# Altium schematic sheet HBridge2B.SchDoc(HBridge2B)
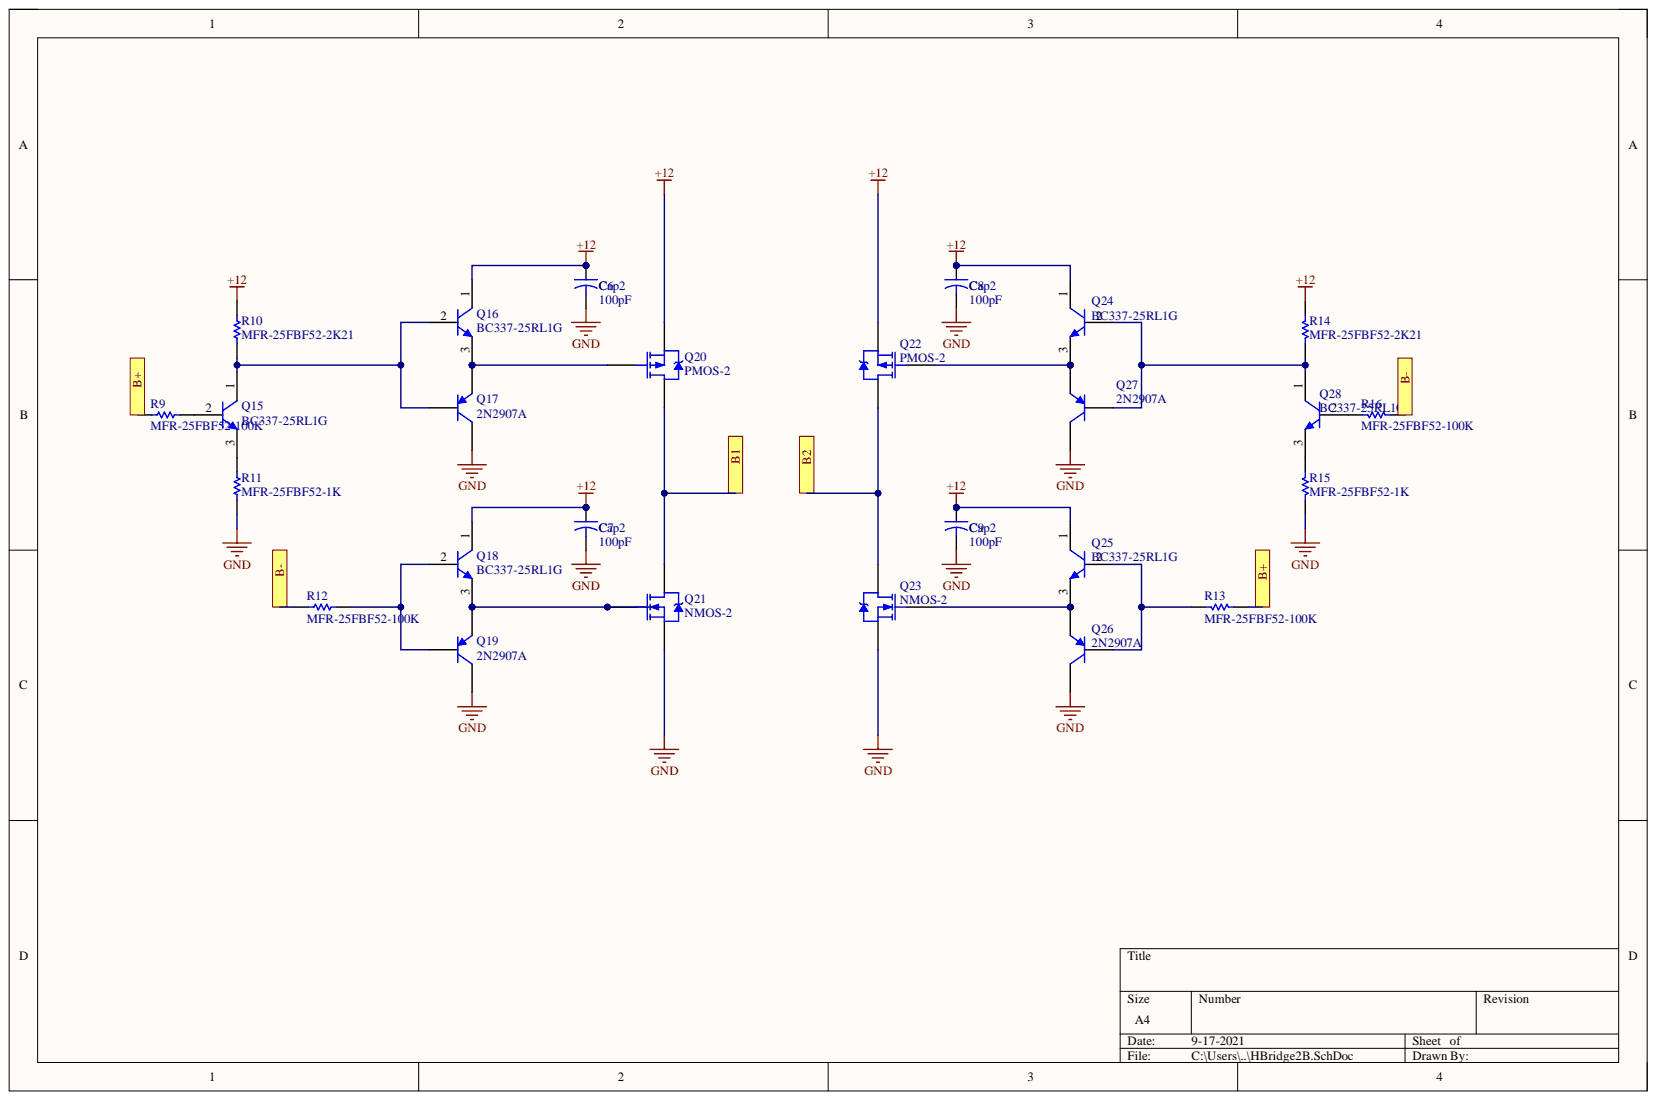
[diagram p0: HBridge2B.SchDoc]

## Parts
C6  Cap2  value 100pF  [AXIAL-0.3]
C7  Cap2  value 100pF  [AXIAL-0.3]
C8  Cap2  value 100pF  [AXIAL-0.3]
C9  Cap2  value 100pF  [AXIAL-0.3]
Q15  BC337-25RL1G  [ONSC-TO-92-3-29-11_AM_BL]
Q16  BC337-25RL1G  [ONSC-TO-92-3-29-11_AM_BL]
Q17  2N2907A  [TO-92A]
Q18  BC337-25RL1G  [ONSC-TO-92-3-29-11_AM_BL]
Q19  2N2907A  [TO-92A]
Q20  PMOS-2  [TO-220AB]
Q21  NMOS-2  [TO-220AB]
Q22  PMOS-2  [TO-220AB]
Q23  NMOS-2  [TO-220AB]
Q24  BC337-25RL1G  [ONSC-TO-92-3-29-11_AM_BL]
Q25  BC337-25RL1G  [ONSC-TO-92-3-29-11_AM_BL]
Q26  2N2907A  [TO-92A]
Q27  2N2907A  [TO-92A]
Q28  BC337-25RL1G  [ONSC-TO-92-3-29-11_AM_BL]
R9  MFR-25FBF52-100K  [RESA60-630X240]
R10  MFR-25FBF52-2K21  [RESA60-630X240]
R11  MFR-25FBF52-1K  [RESA60-630X240]
R12  MFR-25FBF52-100K  [RESA60-630X240]
R13  MFR-25FBF52-100K  [RESA60-630X240]
R14  MFR-25FBF52-2K21  [RESA60-630X240]
R15  MFR-25FBF52-1K  [RESA60-630X240]
R16  MFR-25FBF52-100K  [RESA60-630X240]

## Ports
B1, B2, B+, B-

## Nets
+12: C6.1 C7.1 C8.1 C9.1 Q16.1 Q18.1 Q20.3 Q22.3 Q24.1 Q25.1 R10.2 R14.2
GND: C6.2 C7.2 C8.2 C9.2 Q17.3 Q19.3 Q21.3 Q23.3 Q26.3 Q27.3 R11.1 R15.1
B1: Q20.2 Q21.2 ; port B1
B2: Q22.2 Q23.2 ; port B2
NetQ15_1: Q15.1 Q16.2 Q17.2 R10.1
NetQ15_2: Q15.2 R9.2
NetQ15_3: Q15.3 R11.2
NetQ16_3: Q16.3 Q17.1 Q20.1
NetQ18_2: Q18.2 Q19.2 R12.2
NetQ18_3: Q18.3 Q19.1 Q21.1
NetQ22_1: Q22.1 Q24.3 Q27.1
NetQ23_1: Q23.1 Q25.3 Q26.1
NetQ24_2: Q24.2 Q27.2 Q28.1 R14.1
NetQ25_2: Q25.2 Q26.2 R13.2
NetQ28_2: Q28.2 R16.2
NetQ28_3: Q28.3 R15.2
NetR9_1: R9.1 R13.1
NetR12_1: R12.1 R16.1

## Library data of the parts
C6-C9 = Cap2: Capacitor; Component Kind: Standard; Library Name: Miscellaneous Devices.IntLib; PackageDescription: Capacitor; 2 Leads; PackageReference: CAPR5-4X5; Published: 23-Sep-2002; Signal Integrity: Cap; Simulation: CAP; Publisher: Altium Limited
Q15 Q16 Q18 Q24 Q25 Q28 = CMP-1048-01576-1: Amplifier Transistor, NPN Silicon, 3-Pin TO-92, Pb-Free, Tape and Reel; Manufacturer: On Semiconductor; IC Continuous (A): 0.8; Component Kind: Standard; ComponentLink1Description: Manufacturer URL; ComponentLink1URL: http://www.onsemi.com/; ComponentLink2Description: Datasheet; ComponentLink2URL: http://www.onsemi.com/pub_link/Collateral/BC337-D.PDF; ComponentLink3Description: Package Specification; ComponentLink3URL: http://www.onsemi.com/pub_link/Collateral/MAC97-D.PDF; DatasheetVersion: Rev. 8; Library Name: Altium Content Vault; Mounting Technology: Through Hole; PackageDescription: 3-Pin Transistor Outline, Body 4.825 x 3.685 mm, Pitch 1.27 mm; PackageReference: TO-92-3-29-11_AM_BL; PackageVersion: Rev. AM; Packing: Tape and Reel; PartNumber: BC337-25RL1G; Polarity: NPN; RoHS: TRUE
Q17 Q19 Q26 Q27 = 2N3906: PNP General Purpose Amplifier; Component Kind: Standard; ComponentLink1Description: Manufacturer Link; ComponentLink1URL: http://www.fairchildsemi.com/; ComponentLink2Description: Datasheet; ComponentLink2URL: http://www.fairchildsemi.com/ds/2N%2F2N3906.pdf; DatasheetVersion: 1997; LatestRevisionDate: 15-Jan-2003; LatestRevisionNote: PCB Footprint 'BCY-W3/D4.7' replaced; Library Name: Miscellaneous Devices.IntLib; PackageDescription: TO, Flat Index; 3 In-Line, Axial Leads; Body Dia. 4.5mm; Leads 0.51 x 0.48 mm (max); PackageReference: TO-92A; PackageVersion: Sep-1998; Published: 8-Jun-2000; Signal Integrity: 2N3906; Simulation: 2N3906; Publisher: Altium Limited
Q20 Q22 = PMOS-2: P-Channel Power MOSFET; Note: Pin 4 not drawn and electrically connected to Pin 2.; Component Kind: Standard; ComponentLink1Description: Manufacturer Link; ComponentLink1URL: http://www.irf.com/; DatasheetVersion: PD-9.390D; LatestRevisionDate: 17-Jul-2002; LatestRevisionNote: Re-released for DXP Platform.; Library Name: Miscellaneous Devices.IntLib; PackageDescription: TO, Thru-Hole, Vertical, Heatsink Mounted; 3 In-Line Leads; Pitch 2.54 mm; PackageReference: TO-220AB; PackageVersion: Feb-1997; Published: 8-Jun-2000; Simulation: IRF9510; Publisher: Altium Limited
Q21 Q23 = NMOS-2: N-Channel Power MOSFET; Note: Pin 2 is electrically connected to pin 4.; Component Kind: Standard; ComponentLink1Description: Manufacturer Link; ComponentLink1URL: http://www.irf.com/; DatasheetVersion: PD-9.814; LatestRevisionDate: 17-Jul-2002; LatestRevisionNote: Re-released for DXP Platform.; Library Name: Miscellaneous Devices.IntLib; PackageDescription: TO, Thru-Hole, Vertical, Heatsink Mounted; 3 In-Line Leads; Pitch 2.54 mm; PackageReference: TO-220AB; PackageVersion: Feb-1997; Published: 8-Jun-2000; Simulation: IRF1010; Publisher: Altium Limited
R9 R12 R13 R16 = CMP-1659-00073-1: Axial Resistor, 100 KOhm, +/- 1%, 0.25 W, -55 to 155 degC, 2-Pin THD, RoHS, Bulk; Power Rating: 250 mW; Resistance: 475 Ohm; Voltage Rating: 250 V; Case\Package: Axial; Component Kind: Standard; ComponentLink1Description: Manufacturer URL; ComponentLink1URL: http://www.yageo.com/; ComponentLink2Description: Datasheet; ComponentLink2URL: http://www.yageo.com/documents/recent/Yageo%20LR_MFR_2013.pdf; DatasheetVersion: Apr-13; Length: 0.248 inch; Library Name: Altium Content Vault; Max Operating Temperature: 155 degC; Min Operating Temperature: -55 degC; Mount: Through Hole; Package Quantity: 200; PackageDescription: 2-Pin Axial Lead, Dia 2.4 mm, Body Length 6.3 mm; PackageReference: MFR-25; Packaging: Bulk; RoHSCompliant: true; Tolerance: 1%
R10 R14 = CMP-1659-00065-1: Axial Resistor, 2.21 KOhm, +/- 1%, 0.25 W, -55 to 155 degC, 2-Pin THD, RoHS, Bulk; Case\Package: 0402; Current Rating: 300 mA; Resistance: 0.15 Ohm; Shielding: Unshielded; Case Code (Imperial): 0402; Case Code (Metric): 1005; Component Kind: Standard; ComponentLink1Description: Manufacturer URL; ComponentLink1URL: http://www.yageo.com/; ComponentLink2Description: Datasheet; ComponentLink2URL: http://www.yageo.com/documents/recent/Yageo%20LR_MFR_2013.pdf; DatasheetVersion: Apr-13; Height: 0.02 inch; Length: 0.04 inch; Library Name: Altium Content Vault; Max Operating Temperature: 125 degC; Min Operating Temperature: -55 degC; Mount: Surface Mount; Package Quantity: 10000; PackageDescription: 2-Pin Axial Lead, Dia 2.4 mm, Body Length 6.3 mm; PackageReference: MFR-25; Packaging: Tape and Reel; Pins: 2; Radiation Hardening: No; REACH SVHC: No SVHC; RoHSCompliant: true; Width: 0.02 inch
R11 R15 = CMP-1659-00075-1: Axial Resistor, 1 KOhm, +/- 1%, 250 mW, -55 to 155 degC, 2-Pin THD, RoHS, Bulk; Power Rating: 250 mW; Resistance: 10 kohm; Voltage Rating: 250 V; Case\Package: Axial; Component Kind: Standard; ComponentLink1Description: Manufacturer URL; ComponentLink1URL: http://www.yageo.com/; ComponentLink2Description: Datasheet; ComponentLink2URL: http://www.yageo.com/documents/recent/Yageo%20LR_MFR_2013.pdf; DatasheetVersion: Apr-13; Length: 0.248 inch; Library Name: Altium Content Vault; Max Operating Temperature: 155 degC; Min Operating Temperature: -55 degC; Package Quantity: 200; PackageDescription: 2-Pin Axial Lead, Dia 2.4 mm, Body Length 6.3 mm; PackageReference: MFR-25; Packaging: Bulk; RoHSCompliant: true; Tolerance: 1%
